# Altium schematic sheet main.SchDoc
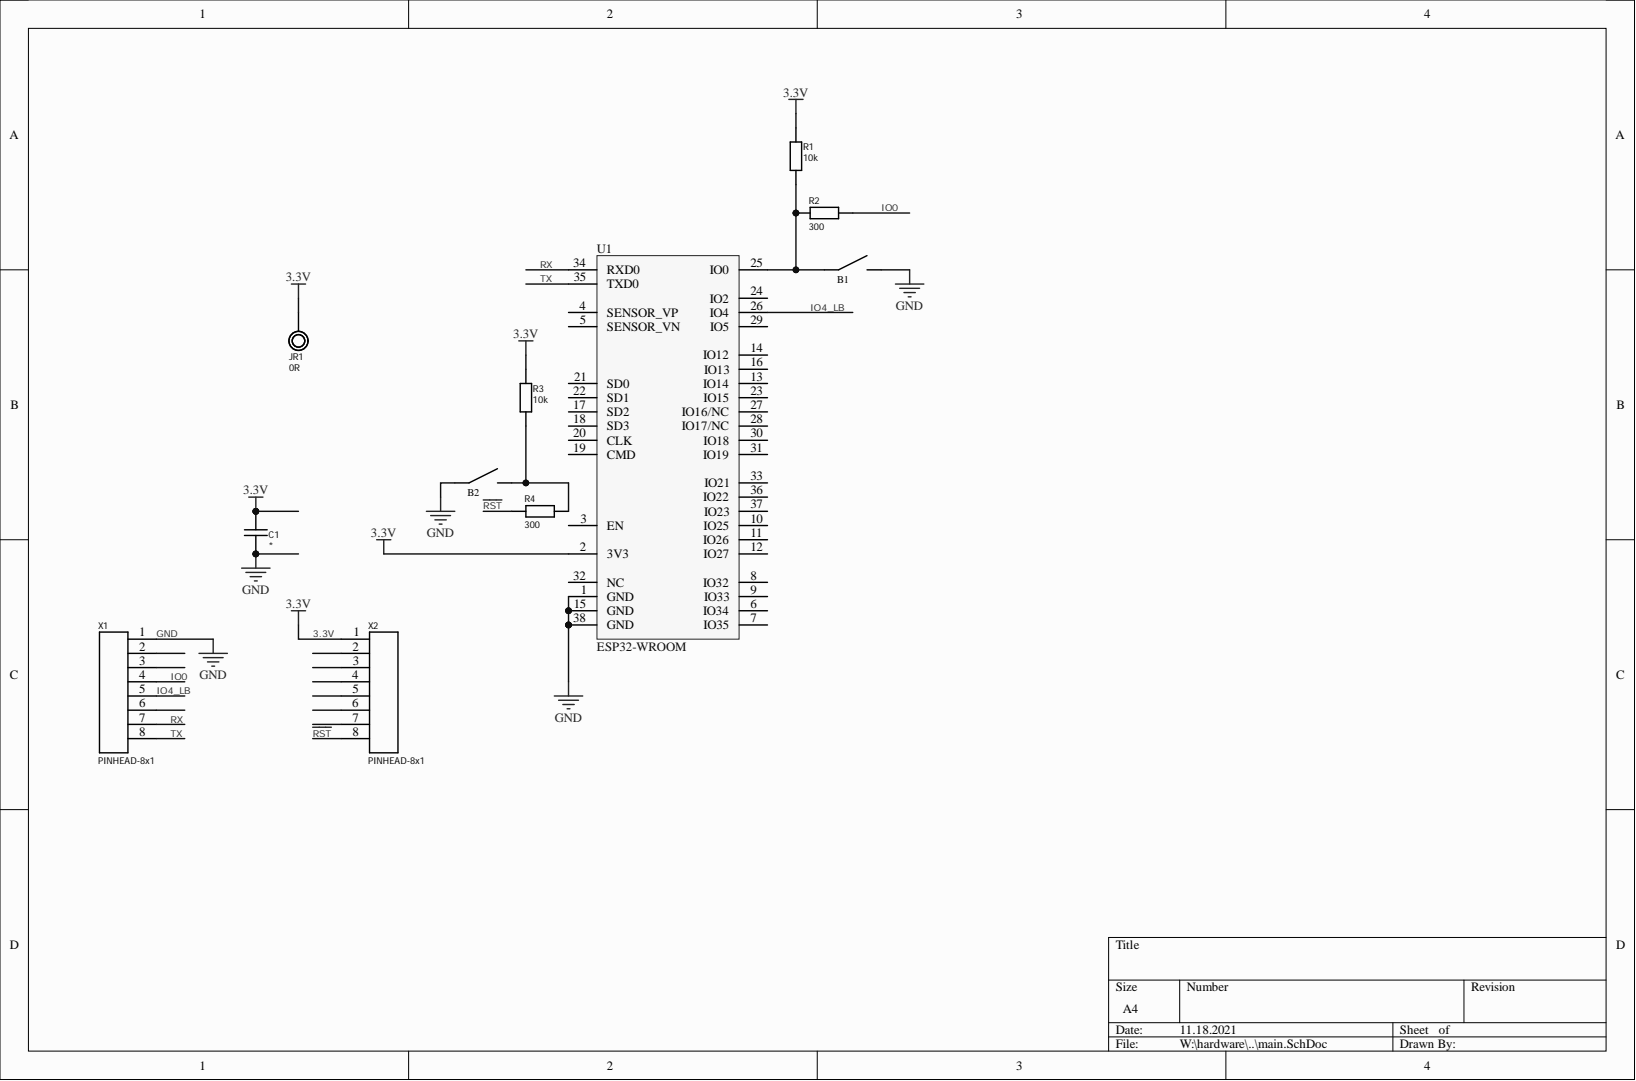
[diagram p0: main.SchDoc]

## Parts
B1  BUTTON  [VE144P05]  (value not drawn)
B2  BUTTON  [VE144P05]  (value not drawn)
C1  (no value)  [C-0805]
JR1  0R  [J-0805W]
R1  10k  [R-0805W]
R2  300  [R-0805]
R3  10k  [R-0805]
R4  300  [R-0805]
U1  ESP32-WROOM  [ESP32-WROOM]
X1  PINHEAD-8x1  [PINHEAD-MALE-8x1]
X2  PINHEAD-8x1  [PINHEAD-MALE-8x1]

## Nets
3.3V: C1.1 JR1.1 R1.2 R3.2 U1.2(3V3) X2.1
GND: B1.2 B2.1 C1.2 U1.1(GND) U1.15(GND) U1.38(GND) X1.1
IO0: R2.2 X1.4
IO4_LB: U1.26(IO4) X1.5
~{RST}: R4.1 X2.8
RX: U1.34(RXD0) X1.7
TX: U1.35(TXD0) X1.8
NetB1_1: B1.1 R1.1 R2.1 U1.25(IO0)
NetB2_2: B2.2 R3.1 R4.2

Not connected: U1 3(EN) 4(SENSOR_VP) 5(SENSOR_VN) 6(IO34) 7(IO35) 8(IO32) 9(IO33) 10(IO25) 11(IO26) 12(IO27) 13(IO14) 14(IO12) 16(IO13) 17(SD2) 18(SD3) 19(CMD) 20(CLK) 21(SD0) 22(SD1) 23(IO15) 24(IO2) 27(IO16/NC) 28(IO17/NC) 29(IO5) 30(IO18) 31(IO19) 32(NC) 33(IO21) 36(IO22) 37(IO23); X1 2 3 6; X2 2 3 4 5 6 7

## Library data of the parts
C1 = CAPACITOR: Component Kind: Standard; Library Name: all-in-one.IntLib
JR1 = JAMPER-RES: Component Kind: Standard; Library Name: all-in-one.IntLib; value: 0R
R1-R4 = RESISTOR: Component Kind: Standard; Library Name: all-in-one.IntLib
  R1 R3: value: 10k
  R2 R4: value: 300
B1 B2 = BUTTON: Component Kind: Standard; Library Name: all-in-one.IntLib
U1 = ESP32-WROOM: Component Kind: Standard; Library Name: all-in-one.IntLib; value: ESP32-WROOM
X1 X2 = PINHEAD-8x1: Component Kind: Standard; Library Name: all-in-one.IntLib
